# Altium schematic sheet LAB02 Analogico-1.SchDoc
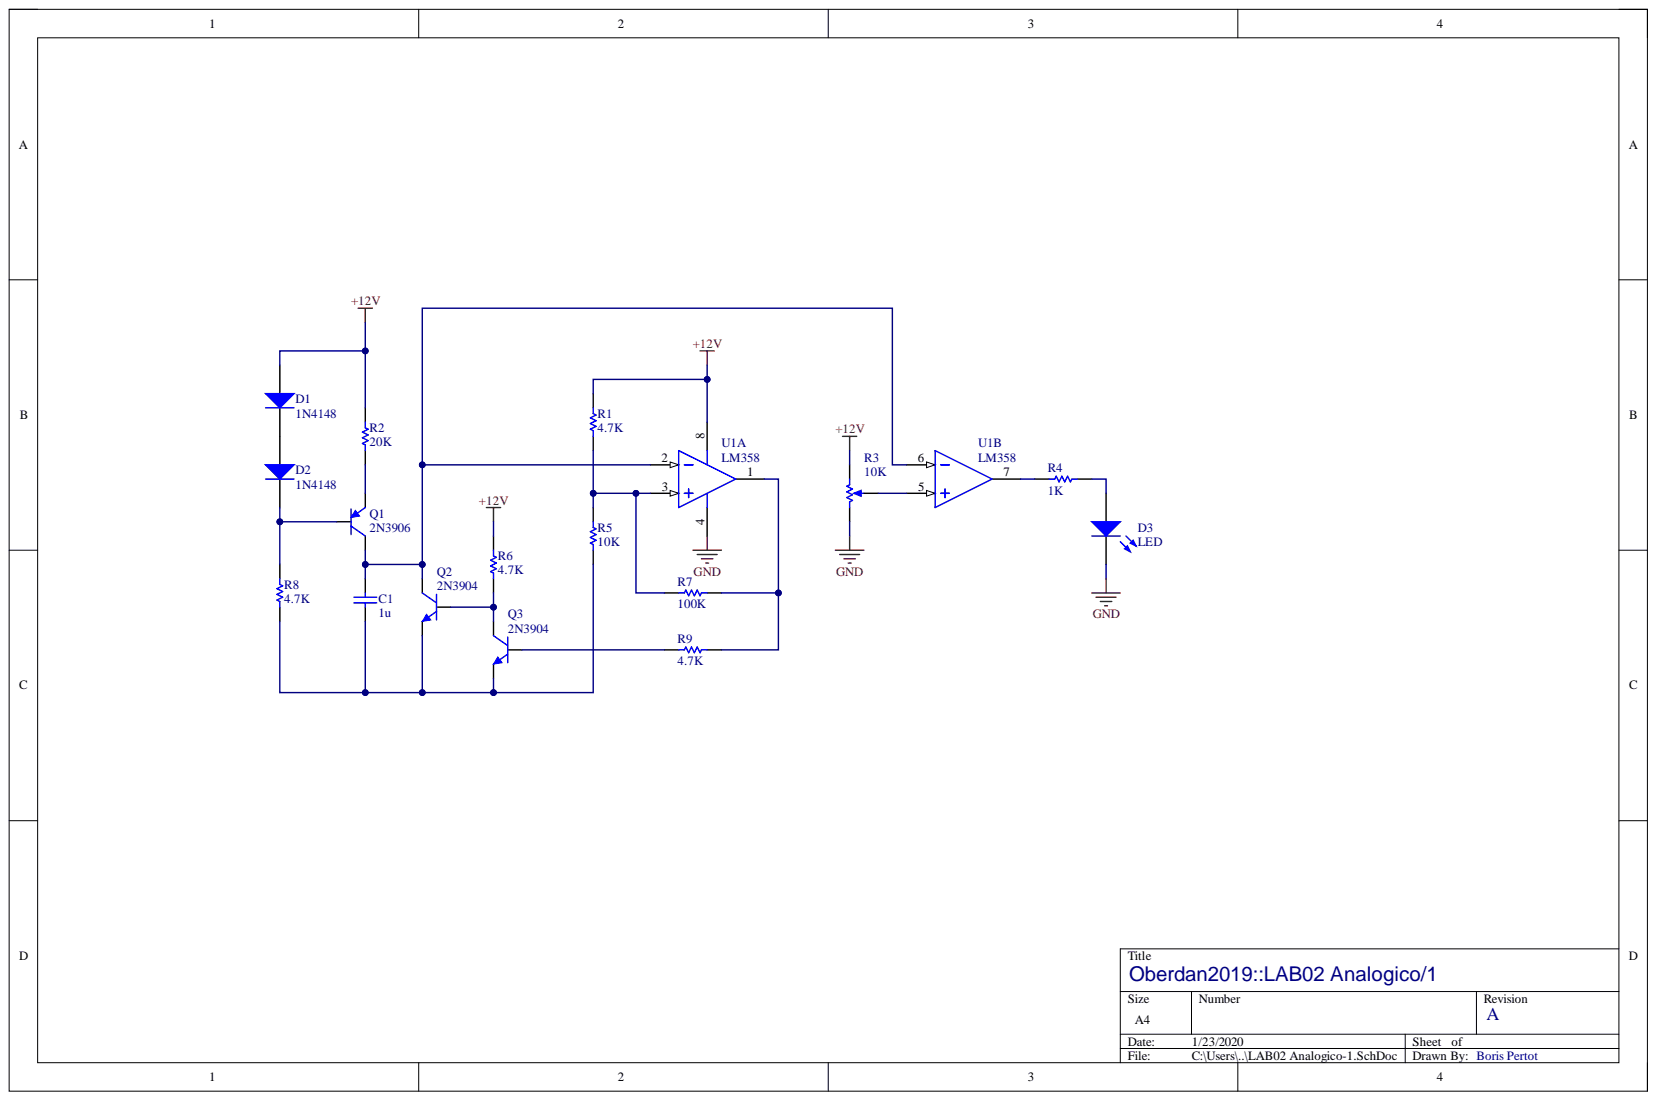
[diagram p0: LAB02 Analogico-1.SchDoc]

## Parts
C1  value 1u  [CAPC2012X12M]  (hidden comment: CL21B105KBFNNNE)
D1  1N4148  [DIOM4426X30M]
D2  1N4148  [DIOM4426X30M]
D3  LED  [LED-0]
Q1  2N3906  [SOT95P245X110-3M]
Q2  2N3904  [SOT95P245X110-3M]
Q3  2N3904  [SOT95P245X110-3M]
R1  value 4.7K  [AXIAL-0.3]  (hidden comment: Res1)
R2  value 20K  [AXIAL-0.3]  (hidden comment: Res1)
R3  value 10K  [VR5]  (hidden comment: RPot)
R4  value 1K  [AXIAL-0.3]  (hidden comment: Res1)
R5  value 10K  [AXIAL-0.3]  (hidden comment: Res1)
R6  value 4.7K  [AXIAL-0.3]  (hidden comment: Res1)
R7  value 100K  [AXIAL-0.3]  (hidden comment: Res1)
R8  value 4.7K  [AXIAL-0.3]  (hidden comment: Res1)
R9  value 4.7K  [AXIAL-0.3]  (hidden comment: Res1)
U1  LM358  [TSOP65P490X95-8M]  (parts A,B)

## Nets
+12V: D1.2 R1.1 R2.1 R3.1 R6.1 U1.8
GND: D3.2 R3.2 U1.4
NetC1_1: C1.1 Q2.2 Q3.2 R5.2 R8.2
NetC1_2: C1.2 Q1.3 Q2.3 U1.2 U1.6
NetD1_1: D1.1 D2.2
NetD2_1: D2.1 Q1.1 R8.1
NetD3_1: D3.1 R4.2
NetQ1_2: Q1.2 R2.2
NetQ2_1: Q2.1 Q3.3 R6.2
NetQ3_1: Q3.1 R9.1
NetR1_2: R1.2 R5.1 R7.1 U1.3
NetR3_3: R3.3 U1.5
NetR4_1: R4.1 U1.7
NetR7_2: R7.2 R9.2 U1.1

## Library data of the parts
C1 = C_1u_50V_0805: 1u 50V 0805 Standard Capacitator; Component Kind: Standard; Signal Integrity: Cap Semi; Simulation: CAP; Supplier 1: Digi-Key; Supplier Part Number 1: 1276-1026-1-ND; Library Name: units_sae_passives.SchLib
D1 D2 = D_S1GTR: DIODE GEN PURP 400V 1A SMA; Component Kind: Standard; Supplier 1: Digi-Key; Supplier Part Number 1: 1655-1504-1-ND; Library Name: units_sae_general.SchLib
D3 = LED0: Typical INFRARED GaAs LED; Component Kind: Standard; LatestRevisionDate: 08-Jul-2005; LatestRevisionNote: Mech Layer 1 removed.; Library Name: Miscellaneous Devices.IntLib; PackageDescription: LED; 2 Leads; PackageReference: LED-0; Published: 8-Jun-2000; Simulation: LED0; Publisher: Altium Limited
Q1 = MMBT3906: 40V PNP SMALL SIGNAL TRANSISTOR IN SOT23; Component Kind: Standard; Supplier 1: Digi-Key; Supplier Part Number 1: MMBT3906LT1GOSCT-ND; Library Name: units_sae_general.SchLib
Q2 Q3 = MMBT3904-7-F: 40V NPN SMALL SIGNAL TRANSISTOR IN SOT23; Component Kind: Standard; Supplier 1: Digi-Key; Supplier Part Number 1: MMBT3904-FDICT-ND; Library Name: units_sae_general.SchLib
R1 R2 R4-R9 = Res1: Resistor; Component Kind: Standard; LatestRevisionDate: 17-Jul-2002; LatestRevisionNote: Re-released for DXP Platform.; Library Name: Miscellaneous Devices.IntLib; PackageDescription: Axial Device, Thru-Hole; 2 Leads; 0.3 in Pin Spacing; PackageReference: AXIAL-0.3; Published: 8-Jun-2000; Signal Integrity: Res; Simulation: RESISTOR; Publisher: Altium Limited
R3 = RPot: Potentiometer; Set Position: 0.5; Sim Note: Enter the Resistance value and the set point into parameter fields.; Component Kind: Standard; LatestRevisionDate: 17-Jul-2002; LatestRevisionNote: Re-released for DXP Platform.; Library Name: Miscellaneous Devices.IntLib; PackageDescription: Variable Resistor, Thru-Hole; 3 Leads; PackageReference: VR5; Published: 8-Jun-2000; Simulation: POT; Publisher: Altium Limited
U1 = TLV1702: Dual high voltage, low power comparator, open collector output; Component Kind: Standard; Supplier 1: Digi-Key; Supplier Part Number 1: 296-37236-1-ND; Library Name: units_sae_general.SchLib
